# Altium schematic sheet Mother.SchDoc(Mother)
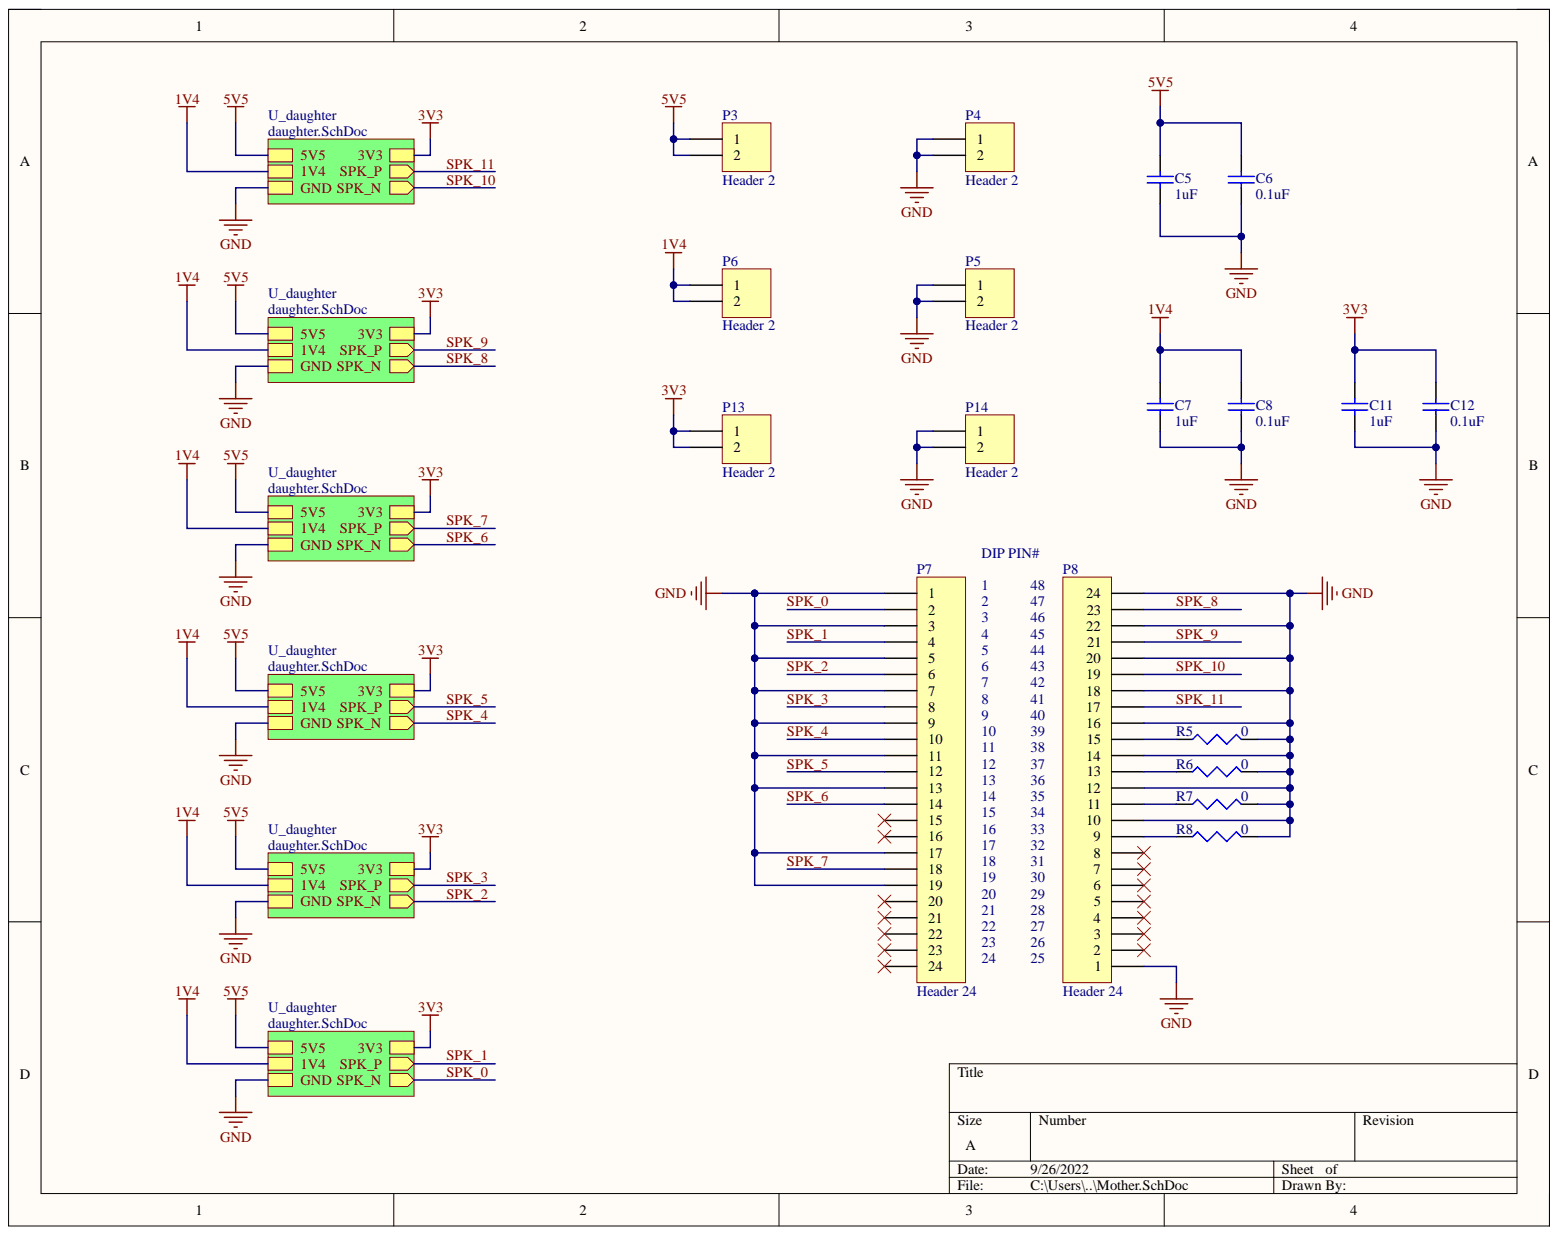
[diagram p0: Mother.SchDoc]

## Parts
C5  1uF  [SMD-0805]
C6  0.1uF  [SMD-0603]
C7  1uF  [SMD-0805]
C8  0.1uF  [SMD-0603]
C11  1uF  [SMD-0805]
C12  0.1uF  [SMD-0603]
P3  Header 2  [HDR1X2]
P4  Header 2  [HDR1X2]
P5  Header 2  [HDR1X2]
P6  Header 2  [HDR1X2]
P7  Header 24  [HDR1X24]
P8  Header 24  [HDR1X24]
P13  Header 2  [HDR1X2]
P14  Header 2  [HDR1X2]
R5  0  [SMD-0603]
R6  0  [SMD-0603]
R7  0  [SMD-0603]
R8  0  [SMD-0603]

## Nets
1V4: C7.2 C8.2 P6.1 P6.2
3V3: C11.2 C12.2 P13.1 P13.2
5V5: C5.2 C6.2 P3.1 P3.2
GND: C5.1 C6.1 C7.1 C8.1 C11.1 C12.1 P4.1 P4.2 P5.1 P5.2 P7.1 P7.3 P7.5 P7.7 P7.9 P7.11 P7.13 P7.17 P7.19 P8.1 P8.10 P8.12 P8.14 P8.16 P8.18 P8.20 P8.22 P8.24 P14.1 P14.2 R5.2 R6.2 R7.2 R8.2
SPK_0: P7.2
SPK_1: P7.4
SPK_2: P7.6
SPK_3: P7.8
SPK_4: P7.10
SPK_5: P7.12
SPK_6: P7.14
SPK_7: P7.18
SPK_8: P8.23
SPK_9: P8.21
SPK_10: P8.19
SPK_11: P8.17
NetP7_15: P7.15
NetP7_16: P7.16
NetP7_20: P7.20
NetP7_21: P7.21
NetP7_22: P7.22
NetP7_23: P7.23
NetP7_24: P7.24
NetP8_2: P8.2
NetP8_3: P8.3
NetP8_4: P8.4
NetP8_5: P8.5
NetP8_6: P8.6
NetP8_7: P8.7
NetP8_8: P8.8
NetP8_9: P8.9 R8.1
NetP8_11: P8.11 R7.1
NetP8_13: P8.13 R6.1
NetP8_15: P8.15 R5.1

## Library data of the parts
C5 C7 C11 = CAP0805: Ceramic Capacitor 0805 Imperial (2012 Metric); Component Kind: Standard; Library Name: LCR.SchLib
C6 C8 C12 = CAP0603: Ceramic Capacitor 0603; Component Kind: Standard; Library Name: LCR.SchLib
P3-P6 P13 P14 = Header 2: Header, 2-Pin; Component Kind: Standard; LatestRevisionDate: 17-Jul-2002; LatestRevisionNote: Re-released for DXP Platform.; Library Name: Miscellaneous Connectors.IntLib; Signal Integrity: Connector; Publisher: Altium Limited
P7 P8 = Header 24: Header, 24-Pin; Component Kind: Standard; LatestRevisionDate: 17-Jul-2002; LatestRevisionNote: Re-released for DXP Platform.; Library Name: Miscellaneous Connectors.IntLib; Signal Integrity: Connector; Publisher: Altium Limited
R5-R8 = RES0603: Resistor-0603; Component Kind: Standard; Library Name: LCR.SchLib
